# Altium schematic sheet modulocks_hangdoboz.SchDoc
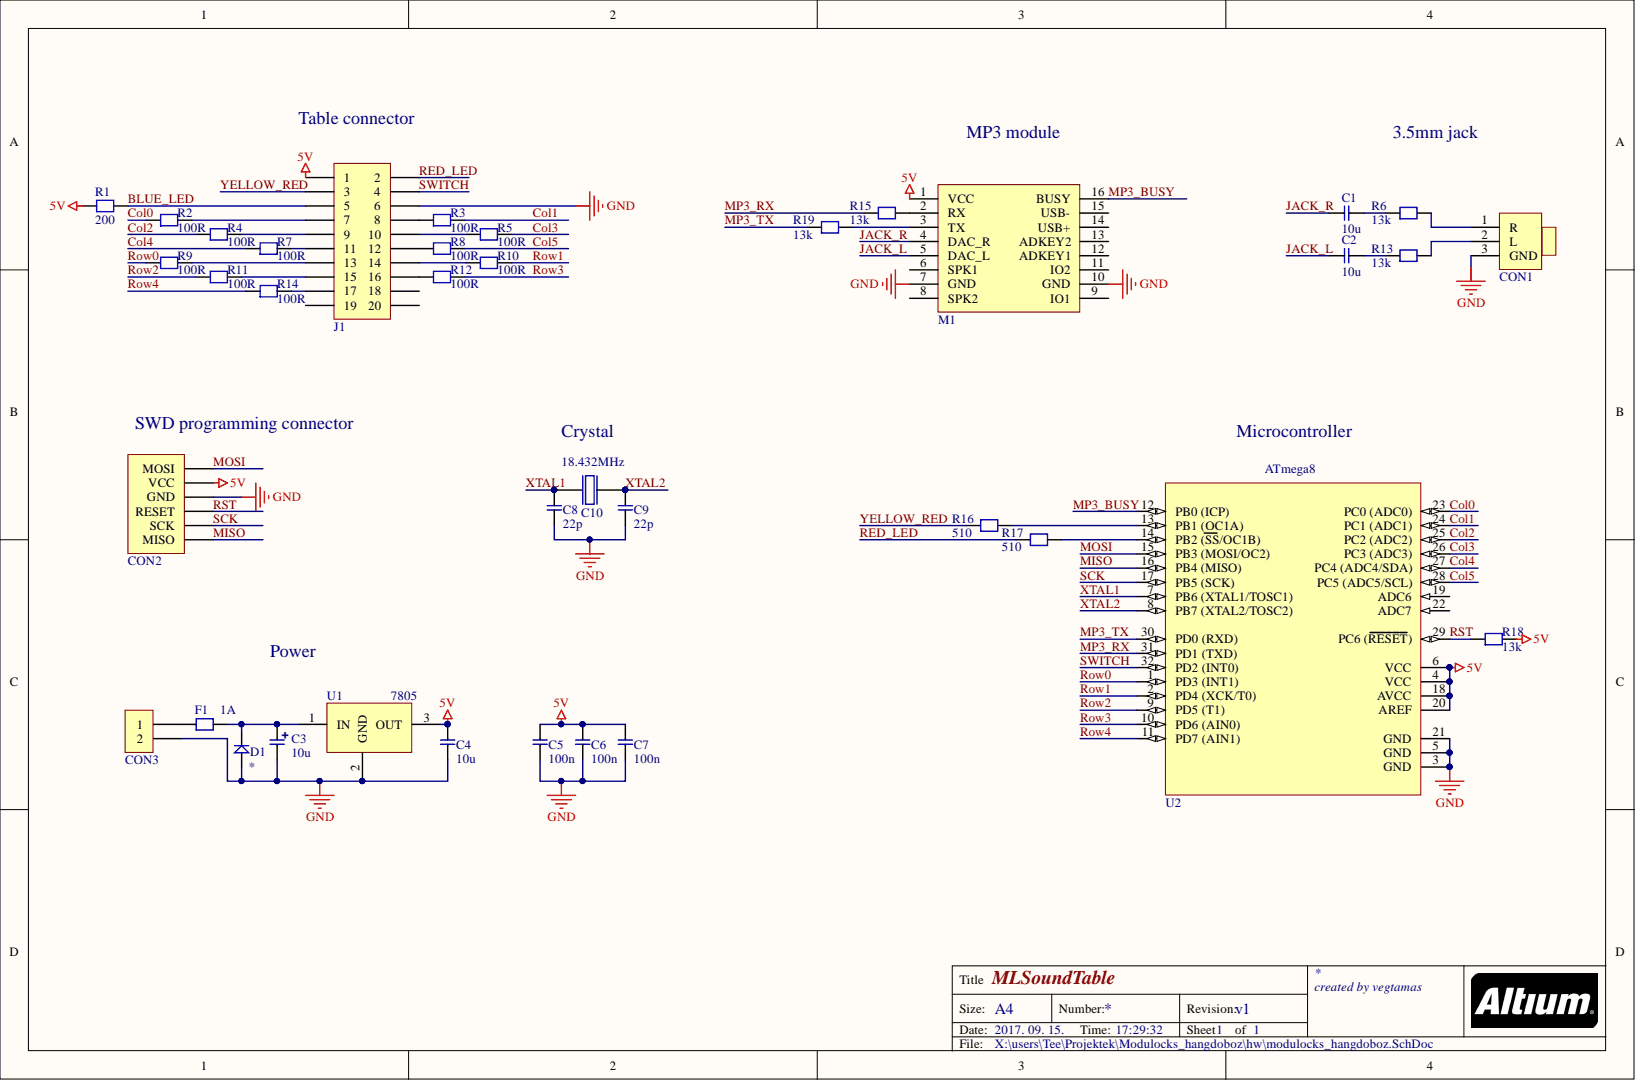
[diagram p0: modulocks_hangdoboz.SchDoc]

## Parts
C1  value 10u  [CAP - 0805]  (hidden comment: capacitor)
C2  value 10u  [CAP - 0805]  (hidden comment: capacitor)
C3  value 10u  [CAP - 5 x 11 rad]  (hidden comment: capacitor - elko)
C4  value 10u  [CAP - 0805]  (hidden comment: capacitor)
C5  value 100n  [CAP - 0805]  (hidden comment: capacitor)
C6  value 100n  [CAP - 0805]  (hidden comment: capacitor)
C7  value 100n  [CAP - 0805]  (hidden comment: capacitor)
C8  value 22p  [CAP - 0805]  (hidden comment: capacitor)
C9  value 22p  [CAP - 0805]  (hidden comment: capacitor)
C10  value 18.432MHz  [HC-49]  (hidden comment: HC-49)
CON1  JS35-09 jack female  [JS35-09 jack female]  (value not drawn)
CON2  AVR ISP10  [PIN HEADER 2x5p]  (value not drawn)
CON3  Terminal block 2p  [Terminal block 2p]  (value not drawn)
D1  Diode  [SMA]  (value not drawn)
F1  Current: 1A  [FUSE 1812]  (hidden comment: Fuse)
J1  10pinx2  [10px2]  (value not drawn)
M1  DFPlayer mini  [DFPlayer mini]  (value not drawn)
R1  value 200  [RES - 0805]  (hidden comment: resistor)
R2  value 100R  [RES - 0805]  (hidden comment: resistor)
R3  value 100R  [RES - 0805]  (hidden comment: resistor)
R4  value 100R  [RES - 0805]  (hidden comment: resistor)
R5  value 100R  [RES - 0805]  (hidden comment: resistor)
R6  value 13k  [RES - 0805]  (hidden comment: resistor)
R7  value 100R  [RES - 0805]  (hidden comment: resistor)
R8  value 100R  [RES - 0805]  (hidden comment: resistor)
R9  value 100R  [RES - 0805]  (hidden comment: resistor)
R10  value 100R  [RES - 0805]  (hidden comment: resistor)
R11  value 100R  [RES - 0805]  (hidden comment: resistor)
R12  value 100R  [RES - 0805]  (hidden comment: resistor)
R13  value 13k  [RES - 0805]  (hidden comment: resistor)
R14  value 100R  [RES - 0805]  (hidden comment: resistor)
R15  value 13k  [RES - 0805]  (hidden comment: resistor)
R16  value 510  [RES - 0805]  (hidden comment: resistor)
R17  value 510  [RES - 0805]  (hidden comment: resistor)
R18  value 13k  [RES - 0805]  (hidden comment: resistor)
R19  value 13k  [RES - 0805]  (hidden comment: resistor)
U1  7805  [TO220]
U2  ATmega8  [TQFP32]

## Nets
5V: C4.1 C5.2 C6.2 C7.2 CON2.2(VCC) J1.1 M1.1(VCC) R1.1 R18.2 U1.3 U2.4(VCC) U2.6(VCC) U2.18(AVCC) U2.20(AREF)
GND: C3.2 C4.2 C5.1 C6.1 C7.1 C8.1 C9.1 CON1.3 CON2.4(GND) CON3.2 D1.1 J1.6 M1.7(GND) M1.10(GND) U1.2 U2.3(GND) U2.5(GND) U2.21(GND)
BLUE_LED: J1.5 R1.2
COL0: R2.1 U2.23(PC0_(ADC0))
COL1: R3.2 U2.24(PC1_(ADC1))
COL2: R4.1 U2.25(PC2_(ADC2))
COL3: R5.2 U2.26(PC3_(ADC3))
COL4: R7.1 U2.27(PC4_(ADC4/SDA))
COL5: R8.2 U2.28(PC5_(ADC5/SCL))
JACK_L: C2.1 M1.5(DAC_L)
JACK_R: C1.1 M1.4(DAC_R)
MISO: CON2.9(MISO) U2.16(PB4_(MISO))
MOSI: CON2.1(MOSI) U2.15(PB3_(MOSI/OC2))
MP3_BUSY: M1.16(BUSY) U2.12(PB0_(ICP))
MP3_RX: R15.1 U2.31(PD1_(TXD))
MP3_TX: R19.1 U2.30(PD0_(RXD))
RED_LED: J1.2 R17.1
ROW0: R9.1 U2.1(PD3_(INT1))
ROW1: R10.2 U2.2(PD4_(XCK/T0))
ROW2: R11.1 U2.9(PD5_(T1))
ROW3: R12.2 U2.10(PD6_(AIN0))
ROW4: R14.1 U2.11(PD7_(AIN1))
RST: CON2.5(RESET) R18.1 U2.29(PC6_(RESET))
SCK: CON2.7(SCK) U2.17(PB5_(SCK))
SWITCH: J1.4 U2.32(PD2_(INT0))
XTAL1: C8.2 C10.2 U2.7(PB6_(XTAL1/TOSC1))
XTAL2: C9.2 C10.1 U2.8(PB7_(XTAL2/TOSC2))
YELLOW_RED: J1.3 R16.1
NetC1_2: C1.2 R6.1
NetC2_2: C2.2 R13.1
NetC3_1: C3.1 D1.2 F1.2 U1.1
NetCON1_1: CON1.1 R6.2
NetCON1_2: CON1.2 R13.2
NetCON3_1: CON3.1 F1.1
NetJ1_7: J1.7 R2.2
NetJ1_8: J1.8 R3.1
NetJ1_9: J1.9 R4.2
NetJ1_10: J1.10 R5.1
NetJ1_11: J1.11 R7.2
NetJ1_12: J1.12 R8.1
NetJ1_13: J1.13 R9.2
NetJ1_14: J1.14 R10.1
NetJ1_15: J1.15 R11.2
NetJ1_16: J1.16 R12.1
NetJ1_17: J1.17 R14.2
NetM1_2: M1.2(RX) R15.2
NetM1_3: M1.3(TX) R19.2
NetR16_2: R16.2 U2.13(PB1_(OC1A))
NetR17_2: R17.2 U2.14(PB2_(SS/OC1B))

Not connected: J1 18 19 20; M1 6(SPK1) 8(SPK2) 9(IO1) 11(IO2) 12(ADKEY1) 13(ADKEY2) 14(USB+) 15(USB-); U2 19(ADC6) 22(ADC7)

## Library data of the parts
C1 C2 C4-C9 = capacitor: Component Kind: Standard; Library Name: passives.SchLib
C3 = capacitor - elko: Component Kind: Standard; Library Name: passives.SchLib
C10 = HC-49: Component Kind: Standard; Library Name: crystals.SchLib
CON1 = JS35-09 jack female: Component Kind: Standard; Library Name: connectors.SchLib
CON2 = AVR ISP10: Component Kind: Standard; Library Name: connectors.SchLib
CON3 = Terminal block 2p: Component Kind: Standard; Library Name: connectors.SchLib
D1 = Diode: Component Kind: Standard; Library Name: diode.SchLib
F1 = Fuse: Component Kind: Standard; Library Name: protection.SchLib
J1 = 10pinx2: Component Kind: Standard; Library Name: pin_headers.SchLib
M1 = DFPlayer mini: Component Kind: Standard; Library Name: modules.SchLib
R1-R19 = resistor: Component Kind: Standard; Library Name: passives.SchLib
U1 = 7805: Component Kind: Standard; Library Name: IC.SchLib
U2 = ATmega8: Component Kind: Standard; Library Name: IC.SchLib
